# Altium schematic sheet LowFreqSignal_V2.SchDoc
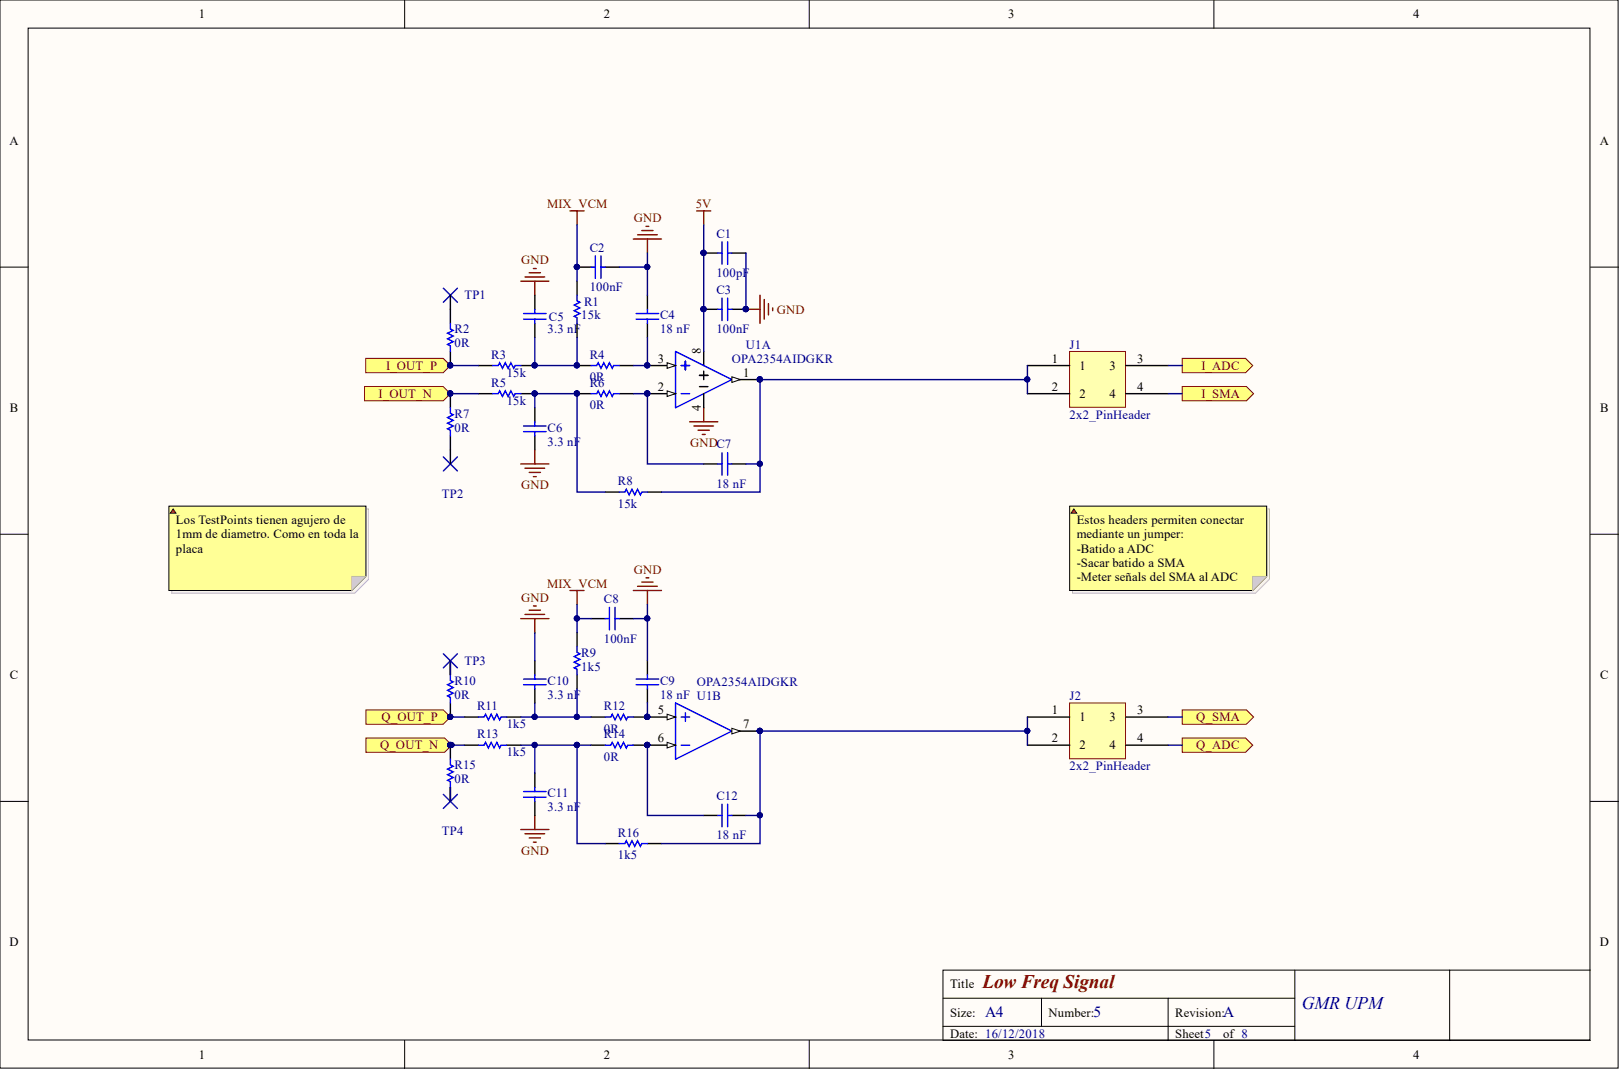
[diagram p0: LowFreqSignal_V2.SchDoc]

## Parts
C1  100pF  [0603 Cap]
C2  100nF  [0603 Cap]
C3  100nF  [0603 Cap]
C4  18 nF  [0603 Cap]
C5  3.3 nF  [0603 Cap]
C6  3.3 nF  [0603 Cap]
C7  18 nF  [0603 Cap]
C8  100nF  [0603 Cap]
C9  18 nF  [0603 Cap]
C10  3.3 nF  [0603 Cap]
C11  3.3 nF  [0603 Cap]
C12  18 nF  [0603 Cap]
J1  2x2_PinHeader  [2x2_PinHeader]
J2  2x2_PinHeader  [2x2_PinHeader]
R1  15k  [0603 Res]
R2  0R  [0603 Res]
R3  15k  [0603 Res]
R4  0R  [0603 Res]
R5  15k  [0603 Res]
R6  0R  [0603 Res]
R7  0R  [0603 Res]
R8  15k  [0603 Res]
R9  1k5  [0603 Res]
R10  0R  [0603 Res]
R11  1k5  [0603 Res]
R12  0R  [0603 Res]
R13  1k5  [0603 Res]
R14  0R  [0603 Res]
R15  0R  [0603 Res]
R16  1k5  [0603 Res]
TP1  TEST_POINT_PIN  [TESTPOINT]  (value not drawn)  (no BOM)
TP2  TEST_POINT_PIN  [TESTPOINT]  (value not drawn)  (no BOM)
TP3  TEST_POINT_PIN  [TESTPOINT]  (value not drawn)  (no BOM)
TP4  TEST_POINT_PIN  [TESTPOINT]  (value not drawn)  (no BOM)
U1  OPA2354AIDGKR  [MSOP-8]  (parts A,B)

## Ports
I_ADC, I_OUT_N, I_OUT_P, I_SMA, Q_ADC, Q_OUT_N, Q_OUT_P, Q_SMA

## Nets
5V: C1.1 C3.1 U1.8
GND: C1.2 C2.2 C3.2 C4.2 C5.2 C6.1 C8.2 C9.2 C10.2 C11.1 U1.4
MIX_VCM: C2.1 C8.1 R1.1 R9.1
I_ADC: J1.3 ; port I_ADC
I_OUT_N: R5.2 R7.2 ; port I_OUT_N
I_OUT_P: R2.2 R3.1 ; port I_OUT_P
I_SMA: J1.4 ; port I_SMA
Q_ADC: J2.4 ; port Q_ADC
Q_OUT_N: R13.2 R15.2 ; port Q_OUT_N
Q_OUT_P: R10.2 R11.2 ; port Q_OUT_P
Q_SMA: J2.3 ; port Q_SMA
NetC4_1: C4.1 R4.1 U1.3
NetC5_1: C5.1 R1.2 R3.2 R4.2
NetC6_2: C6.2 R5.1 R6.2 R8.2
NetC7_1: C7.1 R6.1 U1.2
NetC7_2: C7.2 J1.1 J1.2 R8.1 U1.1
NetC9_1: C9.1 R12.1 U1.5
NetC10_1: C10.1 R9.2 R11.1 R12.2
NetC11_2: C11.2 R13.1 R14.2 R16.2
NetC12_1: C12.1 R14.1 U1.6
NetC12_2: C12.2 J2.1 J2.2 R16.1 U1.7
NetR2_1: R2.1 TP1.1
NetR7_1: R7.1 TP2.1
NetR10_1: R10.1 TP3.1
NetR15_1: R15.1 TP4.1

## Library data of the parts
C1 = CL10B101KB8NNNC: CAP CER 100PF 50V X7R 0603; Manufacturer: Samsung Electro-Mechanics America, Inc.; Tolerance: ±10%; Applications: General Purpose; Category: Capacitors; Component Kind: Standard; ComponentLink1Description: http://media.digikey.com/pdf/Data%20Sheets/Samsung%20PDFs/CL_Series_MLCC_ds.pdf; ComponentLink1URL: http://media.digikey.com/pdf/Data%20Sheets/Samsung%20PDFs/CL_Series_MLCC_ds.pdf; Features: -; Height - Seated (Max): -; Lead Spacing: -; Lead Style: -; Library Name: Componentes_base.SchLib; Manufacturer Part Number: CL10B101KB8NNNC; Mounting Type: Surface Mount, MLCC; Operating Temperature: -55°C ~ 125°C; Package / Case: 0603 (1608 Metric); Packaging: Cut Tape (CT); Part Status: Active; Pricing 1: 1=0.08, 10=0.026, 100=0.0116, 500=0.00832, 1000=0.00653 (EUR); Ratings: -; RoHS: RoHS Compliant; Size / Dimension: 0.063" L x 0.032" W (1.60mm x 0.80mm); Stock 1: 270807; Supplier 1: Digi-Key; Supplier Part Number 1: 1276-1909-1-ND; Temperature Coefficient: X7R; Thickness (Max): 0.035" (0.90mm); Voltage - Rated: 50V
C2 C3 C8 = C0603C104K4RACTU: CAP CER 0.1UF 16V X7R 0603; Manufacturer: KEMET; Capacitance: 0.1µF; Tolerance: ±10%; Applications: General Purpose; Category: Capacitors; Component Kind: Standard; ComponentLink1Description: http://www.kemet.com/docfinder?Partnumber=C0603C104K4RACTU; ComponentLink1URL: http://www.kemet.com/docfinder?Partnumber=C0603C104K4RACTU; Failure Rate: -; Features: -; Height - Seated (Max): -; Lead Spacing: -; Lead Style: -; Library Name: Componentes_base.SchLib; Manufacturer Part Number: C0603C104K4RACTU; Mounting Type: Surface Mount, MLCC; Operating Temperature: -55°C ~ 125°C; Package / Case: 0603 (1608 Metric); Packaging: Digi-Reel®; Part Status: Active; Pricing 1: 1=0.09, 10=0.022, 25=0.016, 50=0.0122, 100=0.01, 250=0.00836, 500=0.0071, 1000=0.00559 (EUR); Ratings: -; RoHS: RoHS Compliant; Size / Dimension: 0.063" L x 0.031" W (1.60mm x 0.80mm); Stock 1: 4491346; Supplier 1: Digi-Key; Supplier Part Number 1: 399-1096-6-ND; Temperature Coefficient: X7R; Thickness (Max): 0.034" (0.87mm); Voltage - Rated: 16V
C4-C7 C9-C12 = C_0603: CAP CER 16V X7R 0603; Material: Ceramic; Tolerance: 10; Voltage: 16; Case/Package: 0603; Case Code (Imperial): 0603; Case Code (Metric): 1608; Component Kind: Standard; Composition: Ceramic; Depth: 0.8; Dielectric: X7R; Height: 0.8; Length: 1.6; Library Name: Componentes_base.SchLib; Mount: Surface Mount; Termination: SMD; Thickness: 0.035; Voltage Rating: 16; Voltage Rating (DC): 16; Width: 0.8
R1-R16 = R_0603: SMD Resistor, 1%, 0.1W, 0603; Power Rating: 100; Tolerance: 1; Case/Package: 0603; Case Code (Imperial): 0603; Case Code (Metric): 1608; Component Kind: Standard; Depth: 0.8; Height: 0.45; Length: 1.6; Library Name: Componentes_base.SchLib; Mount: Surface Mount; Pins: 2; Termination: SMD; Width: 0.8
TP1-TP4 = TEST_POINT_PIN: Test point for single pin; Library Name: Componentes_base.SchLib
U1 = OPA2354AIDGKR: IC OPAMP VFB 100MHZ RRO 8VSSOP; Manufacturer: Texas Instruments; -3db Bandwidth: 250MHz; Amplifier Type: Voltage Feedback; Current - Supply: 4.9mA; Output Type: Rail-to-Rail; Slew Rate: 150 V/µs; Voltage - Supply, Single/Dual (±): 2.5 V ~ 5.5 V, ±1.25 V ~ 2.75 V; Category: Integrated Circuits (ICs); Component Kind: Standard; ComponentLink1Description: http://www.ti.com/lit/ds/symlink/opa354.pdf; ComponentLink1URL: http://www.ti.com/lit/ds/symlink/opa354.pdf; Library Name: Componentes_base.SchLib; Manufacturer Part Number: OPA2354AIDGKR; Mounting Type: Surface Mount; Operating Temperature: -40°C ~ 125°C; Package / Case: 8-TSSOP, 8-MSOP (0.118", 3.00mm Width); Packaging: Cut Tape (CT); Part Status: Active; Pricing 1: 1=2.24, 10=2.013, 100=1.6494, 500=1.4041, 1000=1.18418 (EUR); RoHS: RoHS Compliant; Stock 1: 5751; Supplier 1: Digi-Key; Supplier Device Package: 8-VSSOP; Supplier Part Number 1: 296-12642-1-ND
J1 J2 = 2x2_PinHeader: Component Kind: Standard; Library Name: Schlib1.SchLib
